# Altium schematic sheet Isolated_CAN.SchDoc
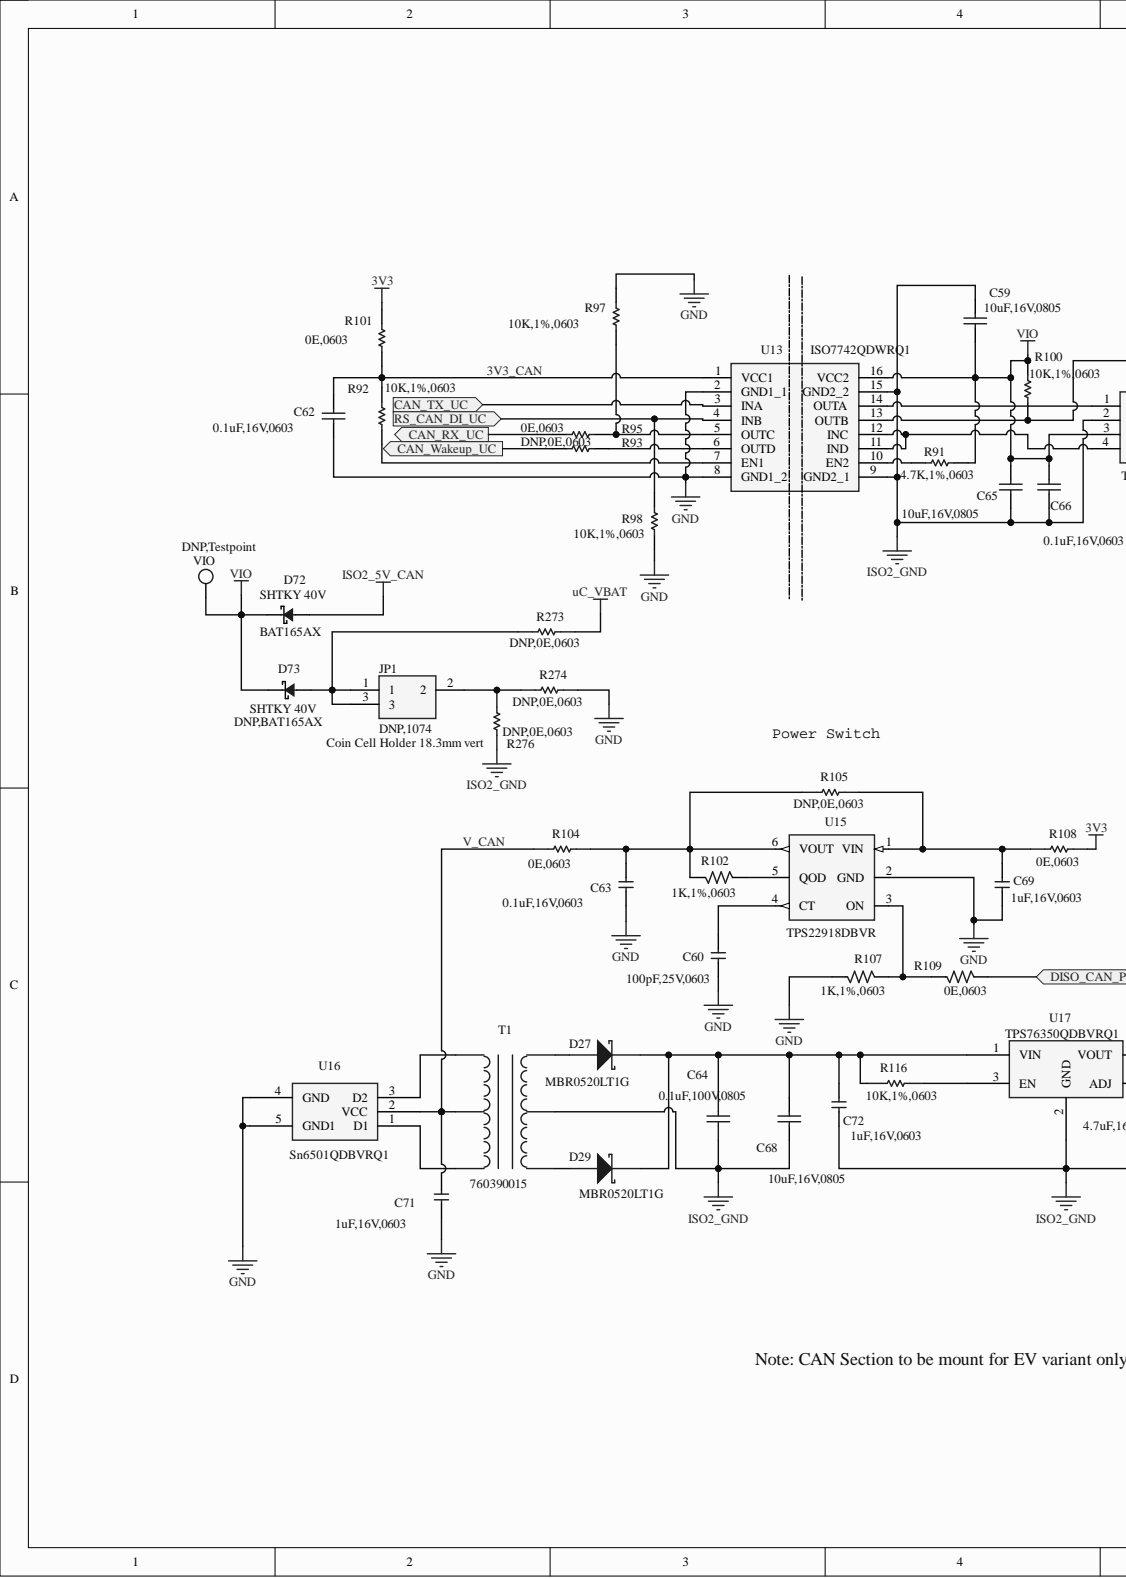
[diagram p0: Isolated_CAN.SchDoc, left]
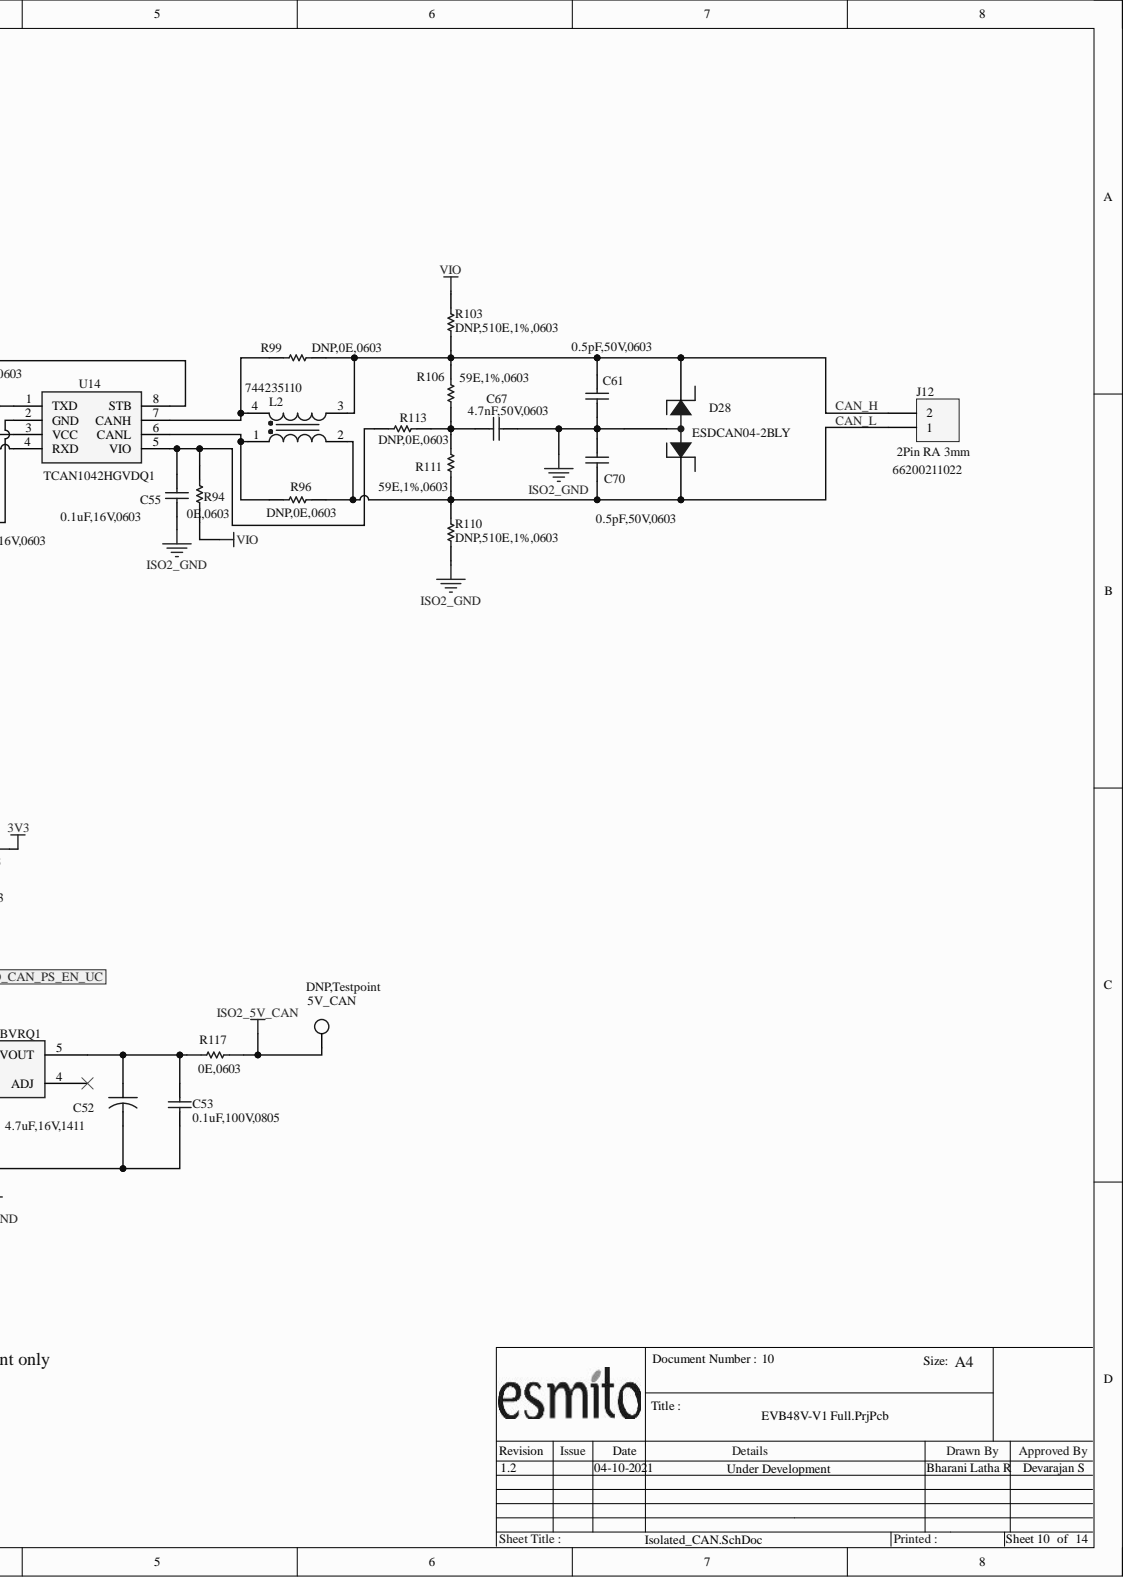
[diagram p1: Isolated_CAN.SchDoc, right]

## Parts
5V_CAN  value DNP,Testpoint  [Keystone5002]  (hidden comment: TEST POINT)
C52  value 4.7uF,16V,1411  [CAPPM3528X210N]  (hidden comment: CAP)
C53  value 0.1uF,100V,0805  [0805_R_M]  (hidden comment: C_Semi)
C55  value 0.1uF,16V,0603  [0603_C_M]  (hidden comment: C_Semi)
C59  value 10uF,16V,0805  [0805_R_M]  (hidden comment: C_Semi)
C60  100pF,25V,0603  [0603_C_M]
C61  value 0.5pF,50V,0603  [0603_C_M]  (hidden comment: C_Semi)
C62  value 0.1uF,16V,0603  [0603_C_M]  (hidden comment: C_Semi)
C63  0.1uF,16V,0603  [0603_C_M]
C64  value 0.1uF,100V,0805  [0805_R_M]  (hidden comment: C_Semi)
C65  value 10uF,16V,0805  [0805_R_M]  (hidden comment: C_Semi)
C66  value 0.1uF,16V,0603  [0603_C_M]  (hidden comment: C_Semi)
C67  value 4.7nF,50V,0603  [0603_C_M]  (hidden comment: C_Semi)
C68  value 10uF,16V,0805  [0805_R_M]  (hidden comment: C_Semi)
C69  1uF,16V,0603  [0603_C_M]
C70  value 0.5pF,50V,0603  [0603_C_M]  (hidden comment: C_Semi)
C71  1uF,16V,0603  [0603_C_M]
C72  1uF,16V,0603  [0603_C_M]
D27  value MBR0520LT1G  [SOD3715X135N]  (hidden comment: D_Schottky_MBR0520L)
D28  ESDCAN04-2BLY  [SOT23_3_L]
D29  value MBR0520LT1G  Part Number: MBR0520LT1G  [SOD3715X135N]  (hidden comment: D_Schottky_MBR0520L)
D72  SHTKY 40V  value BAT165AX  Part Number: BAT165AX  [SOD_323_M]
D73  SHTKY 40V  value DNP,BAT165AX  [SOD_323_M]
J12  2Pin RA 3mm  value 66200211022  [66200211022]
JP1  Coin Cell Holder 18.3mm vert  value DNP,1074  [1074]
L2  value 744235110  [WE-CNSW_1812]  (hidden comment: 5000Ohm)
R91  value 4.7K,1%,0603  [0603_R_M]  (hidden comment: R_Semi)
R92  value 10K,1%,0603  [0603_R_M]  (hidden comment: R_Semi)
R93  value DNP,0E,0603  [0603_R_M]  (hidden comment: R_Semi)
R94  value 0E,0603  [0603_R_M]  (hidden comment: R_Semi)
R95  value 0E,0603  [0603_R_M]  (hidden comment: R_Semi)
R96  value DNP,0E,0603  [0603_R_M]  (hidden comment: R_Semi)
R97  value 10K,1%,0603  [0603_R_M]  (hidden comment: R_Semi)
R98  value 10K,1%,0603  [0603_R_M]  (hidden comment: R_Semi)
R99  value DNP,0E,0603  [0603_R_M]  (hidden comment: R_Semi)
R100  value 10K,1%,0603  [0603_R_M]  (hidden comment: R_Semi)
R101  value 0E,0603  [0603_R_M]  (hidden comment: R_Semi)
R102  1K,1%,0603  [0603_R_M]
R103  value DNP,510E,1%,0603  [0603_R_M]  (hidden comment: R_Semi)
R104  value 0E,0603  [0603_R_M]  (hidden comment: R_Semi)
R105  value DNP,0E,0603  [0603_R_M]  (hidden comment: R_Semi)
R106  value 59E,1%,0603  [0603_R_M]  (hidden comment: R_Semi)
R107  1K,1%,0603  [0603_R_M]
R108  value 0E,0603  [0603_R_M]  (hidden comment: R_Semi)
R109  0E,0603  [0603_R_M]
R110  value DNP,510E,1%,0603  [0603_R_M]  (hidden comment: R_Semi)
R111  value 59E,1%,0603  [0603_R_M]  (hidden comment: R_Semi)
R113  value DNP,0E,0603  [0603_R_M]  (hidden comment: R_Semi)
R116  value 10K,1%,0603  [0603_R_M]  (hidden comment: R_Semi)
R117  value 0E,0603  [0603_R_M]  (hidden comment: R_Semi)
R273  value DNP,0E,0603  [0603_R_M]  (hidden comment: R_Semi)
R274  value DNP,0E,0603  [0603_R_M]  (hidden comment: R_Semi)
R276  value DNP,0E,0603  [0603_R_M]  (hidden comment: R_Semi)
T1  value 760390015  Part Number: 760390015  [SOP254P1000X419-6N]  (hidden comment: TR_Centre Tap Transformer_T2)
U13  ISO7742QDWRQ1  [SOIC127P1030X265-16M]
U14  TCAN1042HGVDQ1  [SOIC127P600X175-8N]
U15  TPS22918DBVR  [SOT95P280X145-6N]
U16  Sn6501QDBVRQ1  [SOT95P280X145-5N]
U17  TPS76350QDBVRQ1  [SOT95P280X145-5N]
VIO  value DNP,Testpoint  [Keystone5002]  (hidden comment: TEST POINT)

## Ports
CAN_RX_UC, CAN_TX_UC, CAN_Wakeup_UC, DISO_CAN_PS_EN_UC, RS_CAN_DI_UC

## Nets
3V3: R101.2 R108.2
GND: C60.1 C62.1 C63.1 C69.1 C71.1 R97.2 R98.1 R107.1 R274.2 U13.2(GND1_1) U13.8(GND1_2) U15.2(GND) U16.4(GND) U16.5(GND1)
ISO2_5V_CAN: 5V_CAN.1 D72.1 R117.2
ISO2_GND: C52.2 C53.1 C55.2 C59.2 C61.1 C64.1 C65.1 C66.1 C67.2 C68.1 C70.2 C72.2 D28.3 R110.2 R276.1 T1.5 U13.9(GND2_1) U13.15(GND2_2) U14.2(GND) U17.2(GND)
uC_VBAT: R273.2
VIO: C59.1 C65.2 C66.2 D72.2 D73.2 R91.1 R94.1 R100.2 R103.1 U13.16(VCC2) U14.3(VCC) VIO.1
3V3_CAN: C62.2 R92.2 R101.1 U13.1(VCC1)
CAN_H: C61.2 D28.1 J12.2 L2.3 R99.2 R103.2 R106.1
CAN_L: C70.1 D28.2 J12.1 L2.2 R96.2 R110.1 R111.2
CAN_RX_UC: R95.2 ; port CAN_RX_UC
CAN_TX_UC: U13.3(INA) ; port CAN_TX_UC
CAN_Wakeup_UC: R93.2 ; port CAN_Wakeup_UC
DISO_CAN_PS_EN_UC: R109.2 ; port DISO_CAN_PS_EN_UC
RS_CAN_DI_UC: R98.2 U13.4(INB) ; port RS_CAN_DI_UC
V_CAN: C71.2 R104.1 T1.2 U16.2(VCC)
NetC52_1: C52.1 C53.2 R117.1 U17.5(VOUT)
NetC55_1: C55.1 R94.2 R113.1 U14.5(VIO)
NetC60_2: C60.2 U15.4(CT)
NetC63_2: C63.2 R102.1 R104.2 R105.1 U15.6(VOUT)
NetC64_2: C64.2 C68.2 C72.1 D27.2 D29.2 R116.1 U17.1(VIN)
NetC67_1: C67.1 R106.2 R111.1 R113.2
NetC69_2: C69.2 R105.2 R108.1 U15.1(VIN)
NetD27_1: D27.1 T1.4
NetD29_1: D29.1 T1.6
NetD73_1: D73.1 JP1.1 JP1.3 R273.1
NetJP1_2: JP1.2 R274.1 R276.2
NetL2_1: L2.1 R96.1 U14.6(CANL)
NetL2_4: L2.4 R99.1 U14.7(CANH)
NetR91_2: R91.2 U13.10(EN2)
NetR92_1: R92.1 U13.7(EN1)
NetR93_1: R93.1 U13.6(OUTD)
NetR95_1: R95.1 R97.1 U13.5(OUTC)
NetR100_1: R100.1 U13.13(OUTB) U14.8(STB)
NetR102_2: R102.2 U15.5(QOD)
NetR107_2: R107.2 R109.1 U15.3(ON)
NetR116_2: R116.2 U17.3(EN)
NetT1_1: T1.1 U16.3(D2)
NetT1_3: T1.3 U16.1(D1)
NetU13_11: U13.11(IND) U13.12(INC) U14.4(RXD)
NetU13_14: U13.14(OUTA) U14.1(TXD)

Not connected: U17 4(ADJ)

## Library data of the parts
5V_CAN VIO = T POINT R: PCB TEST POINT BLACK 1mm; Manufacturer: Keystone Electronics; Part Number: 5001; Component Kind: Standard
C52 = CAP: TANT 16V 4.7uF 1411 10%; Manufacturer: KEMET; Part Number: T494B475K016; Value: 4.7uF,16V,1411; Component Kind: Standard
C53 = C_Semi: MLCC 100V 100nF X7R 0805 10%; Manufacturer: AVX; Part Number: 08051C104K4T2A; Component Kind: Standard; Library Name: CLVESB-R10.SCHLIB
C55 C62 C66 = C_Semi: MLCC - 0603 100nF 16V X7R 10%; Alternate Manufacturer: AVX; Alternate Part Number: 0603YC104K4T2A; Manufacturer: Yageo; Part Number: CC603KRX7R7BB104; Component Kind: Standard; Signal Integrity: Cap Semi; Simulation: CAP; Library Name: Grinntech_Global_Library.IntLib
C59 C65 C68 = C_Semi: MLCC - 0805 16V 10uF X7R 10 %; Alternate Manufacturer: Taiyo Yuden; Alternate Part Number: EMK212BB7106KG-T; Manufacturer: Yageo; Part Number: CC0805KKX7R7BB106; Component Kind: Standard; Signal Integrity: Cap Semi; Simulation: CAP; Library Name: Grinntech_Global_Library.IntLib
C60 = C_11: MLCC - 0603 25V 100pF X7R 10%; Manufacturer: AVX; Part Number: 06033C101K4T2A; Component Kind: Standard; Simulation: C_11; Value: 100pF,25V,0603
C61 C70 = C_Semi: MLCC 50V 0.5pF +/-0.1pF; Manufacturer: Yageo; Part Number: AQ0603BRNPO9BNR50; Value: 0.5pF,50V,0603; Component Kind: Standard; Signal Integrity: Cap Semi; Simulation: CAP; Library Name: Grinntech_Global_Library.IntLib
C63 = C_11: MLCC - 0603 100nF 16V X7R 10%; Alternate Manufacturer: AVX; Alternate Part Number: 0603YC104K4T2A; Manufacturer: Yageo; Part Number: CC603KRX7R7BB104; Component Kind: Standard; Simulation: C_11; Value: 0.1uF,16V,0603
C64 = C_Semi_C127: MLCC 100V 100nF X7R 0805 10%; Manufacturer: AVX; Part Number: 08051C104K4T2A; Component Kind: Standard; Library Name: CLVESB-R10.SCHLIB
C67 = C_Semi: MLCC - 0603 4.7nF 50V 10%; Manufacturer: Yageo; Part Number: CC0603KRX7R9BB472; Component Kind: Standard; Signal Integrity: Cap Semi; Simulation: CAP; Library Name: Grinntech_Global_Library.IntLib
C69 C71 C72 = C_11: MLCC - 0603 1uF 16V X5R 10%; Alternate Manufacturer: Murata; Alternate Part Number: GCM188R71C105KA64D; Manufacturer: Yageo; Part Number: CC603KRX5R7BB105; Component Kind: Standard; Value: 1uF,16V,0603
  C71: Simulation: C_11
D27 = D_Schottky_D46: DIODE SCHOTTKY 20V 500MA SOD123; Manufacturer: ON Semiconductor; Part Number: MBR0520LT1G; Value: MBR0520LT1G; Component Kind: Standard
D28 = SM712-02HTG: Automotive dual-line TVS in SOT23-3L for CAN 27.5V; Manufacturer: STMicroelectronics; Component Kind: Standard; Part Number: ESDCAN04-2BLY; Value: ESDCAN04-2BLY
D29 = D_Schottky_D47: DIODE SCHOTTKY 20V 500MA SOD123; Manufacturer: ON Semiconductor; Component Kind: Standard
D72 = BAT42WS-7-F_1: Schottky Diode 40V 0.75A; Manufacturer: Nexperia; Component Kind: Standard; Library Name: PSFB_uC_RS485.SCHLIB
D73 = BAT42WS-7-F_1: Schottky Diode 40V 0.75A; Manufacturer: Nexperia; Part Number: BAT165AX; Component Kind: Standard; Library Name: PSFB_uC_RS485.SCHLIB
J12 = Header 2: WR-MPC3 3.00 mm Male Single Row Angled Header 2Pin w. Plastic Pegs; Manufacturer: Wurth Elektronik; Component Kind: Standard; Part Number: 66200211022; Signal Integrity: Connector; Library Name: PSFB_uC_RS485.SCHLIB
JP1 = 1074: VERTICAL THM COIN CELL HOLDER; Manufacturer: Keystone Electronics; Component Kind: Standard; Part Number: 1074
L2 = 744235110: WE-CNSW SMT Common Mode Line Filter, size 1812, 5000Ohm, 0.45A, 60V; Manufacturer: Wurth Elektronik; Component Kind: Standard; Library Name: 744235110_Altium_WE-CNSW_rev20a.IntLib; Part Number: 744235110
R91 = R_Semi: Thick Film Resistor - 0603 1/10watt 4.7K 1%; Manufacturer: Vishay; Part Number: CRCW06034K70FKEA; Component Kind: Standard; Signal Integrity: Res Semi; Simulation: RES; Library Name: Grinntech_Global_Library.IntLib
R92 R97 R98 R100 = R_Semi: R, THK FLM, 10K, 1%, 100mW, 0603; Alternate Manufacturer: Vishay; Alternate Part Number: CRCW060310K0FKEA; Manufacturer: Yageo; Part Number: RC0603FR-0710KL; Component Kind: Standard; Signal Integrity: Res Semi; Simulation: RES; Library Name: Grinntech_Global_Library.IntLib
R93-R96 R99 R101 R113 = R_Semi: R, THK FLM, 0E,100mW,  0603; Alternate Manufacturer: Vishay; Alternate Part Number: CRCW06030000Z0EAC; Manufacturer: Yageo; Part Number: RC0603FR-070RL; Component Kind: Standard; Signal Integrity: Res Semi; Simulation: RES; Library Name: Grinntech_Global_Library.IntLib
R102 R107 = R_1: R, THK FLM, 1K, 1%, 100mW, 0603; Alternate Manufacturer: Vishay; Alternate Part Number: CRCW06031K00FKEA; Manufacturer: Yageo; Part Number: RC0603FR-071KL; Component Kind: Standard; Value: 1K,1%,0603
  R102: Simulation: R_1
R103 R110 = R_Semi: Thick Film Resistor - 0603 1/10watt 510E 1%; Manufacturer: Vishay; Part Number: CRCW0603510RFKEA; Component Kind: Standard; Signal Integrity: Res Semi; Simulation: RES; Library Name: Grinntech_Global_Library.IntLib
R104 R105 R108 R117 R273 R274 R276 = R_Semi_R245: R, THK FLM, 0E,100mW,  0603; Alternate Manufacturer: Vishay; Alternate Part Number: CRCW06030000Z0EAC; Manufacturer: Yageo; Part Number: RC0603FR-070RL; Component Kind: Standard; Library Name: CLVESB-R10.SCHLIB
R106 R111 = R_Semi: Thick Film Resistor - 0603 1/10watt 59E 1%; Manufacturer: Vishay; Part Number: CRCW060359R0FKEA; Component Kind: Standard; Signal Integrity: Res Semi; Simulation: RES; Library Name: Grinntech_Global_Library.IntLib
R109 = R_1: R, THK FLM, 0E,100mW,  0603; Alternate Manufacturer: Vishay; Alternate Part Number: CRCW06030000Z0EAC; Manufacturer: Yageo; Part Number: RC0603FR-070RL; Component Kind: Standard; Value: 0E,0603
R116 = R_Semi_R246: R, THK FLM, 10K, 1%, 100mW, 0603; Alternate Manufacturer: Vishay; Alternate Part Number: CRCW060310K0FKEA; Manufacturer: Yageo; Part Number: RC0603FR-0710KL; Component Kind: Standard
T1 = TR_Centre Tap Transformer_T2: TR 475UH SMD; Manufacturer: Wurth Elektronik; Component Kind: Standard; Library Name: CLVESB-R10.SCHLIB
U13 = ISO7742QDWQ1: Quad-Channel 2 Fwd 2 Rev Digital Isolator; Alternate Manufacturer: Texas Instruments; Part Number: ISO7742DWR; Alternate Part Number: ISO7742QDWRQ1; Component Kind: Standard; Manufacturer: Texas Instruments; Value: ISO7742QDWRQ1; Library Name: CLVESB-R10.SCHLIB
U14 = TCAN1042HGVDQ1: CAN Interface IC; Alternate Manufacturer: Texas Instruments; Alternate Part Number: TCAN1042HGVDQ1; Component Kind: Standard; Manufacturer: Texas Instruments; Part Number: TCAN1042HGVD; Value: TCAN1042HGVDQ1
U15 = C78L32/SO_2: Power Switch  5.5V 2A; Alternate Manufacturer: Texas Instruments; Alternate Part Number: TPS22918TDBVTQ1; Component Kind: Standard; Manufacturer: Texas Instruments; Part Number: TPS22918DBVR; Value: TPS22918DBVR
U16 = Sn6501QDBVRQ1: PMIC Auto Transformer Dvr; Alternate Manufacturer: Texas Instruments; Part Number: SN6501DBVR; Alternate Part Number: Sn6501QDBVRQ1; Component Kind: Standard; Manufacturer: Texas Instruments; Value: Sn6501QDBVRQ1; Library Name: CLVESB-R10.SCHLIB
U17 = TPS76350QDBVRQ1: IC REG LINEAR 5V 150MA SOT23-5; Alternate Manufacturer: Texas Instruments; Part Number: TPS76350DBVR; Alternate Part Number: TPS76350QDBVRQ1; Component Kind: Standard; Manufacturer: Texas Instruments; Value: TPS76350QDBVRQ1; Library Name: PSFB_uC_RS485.SCHLIB
